# Altium schematic sheet Controlador temp.SchDoc
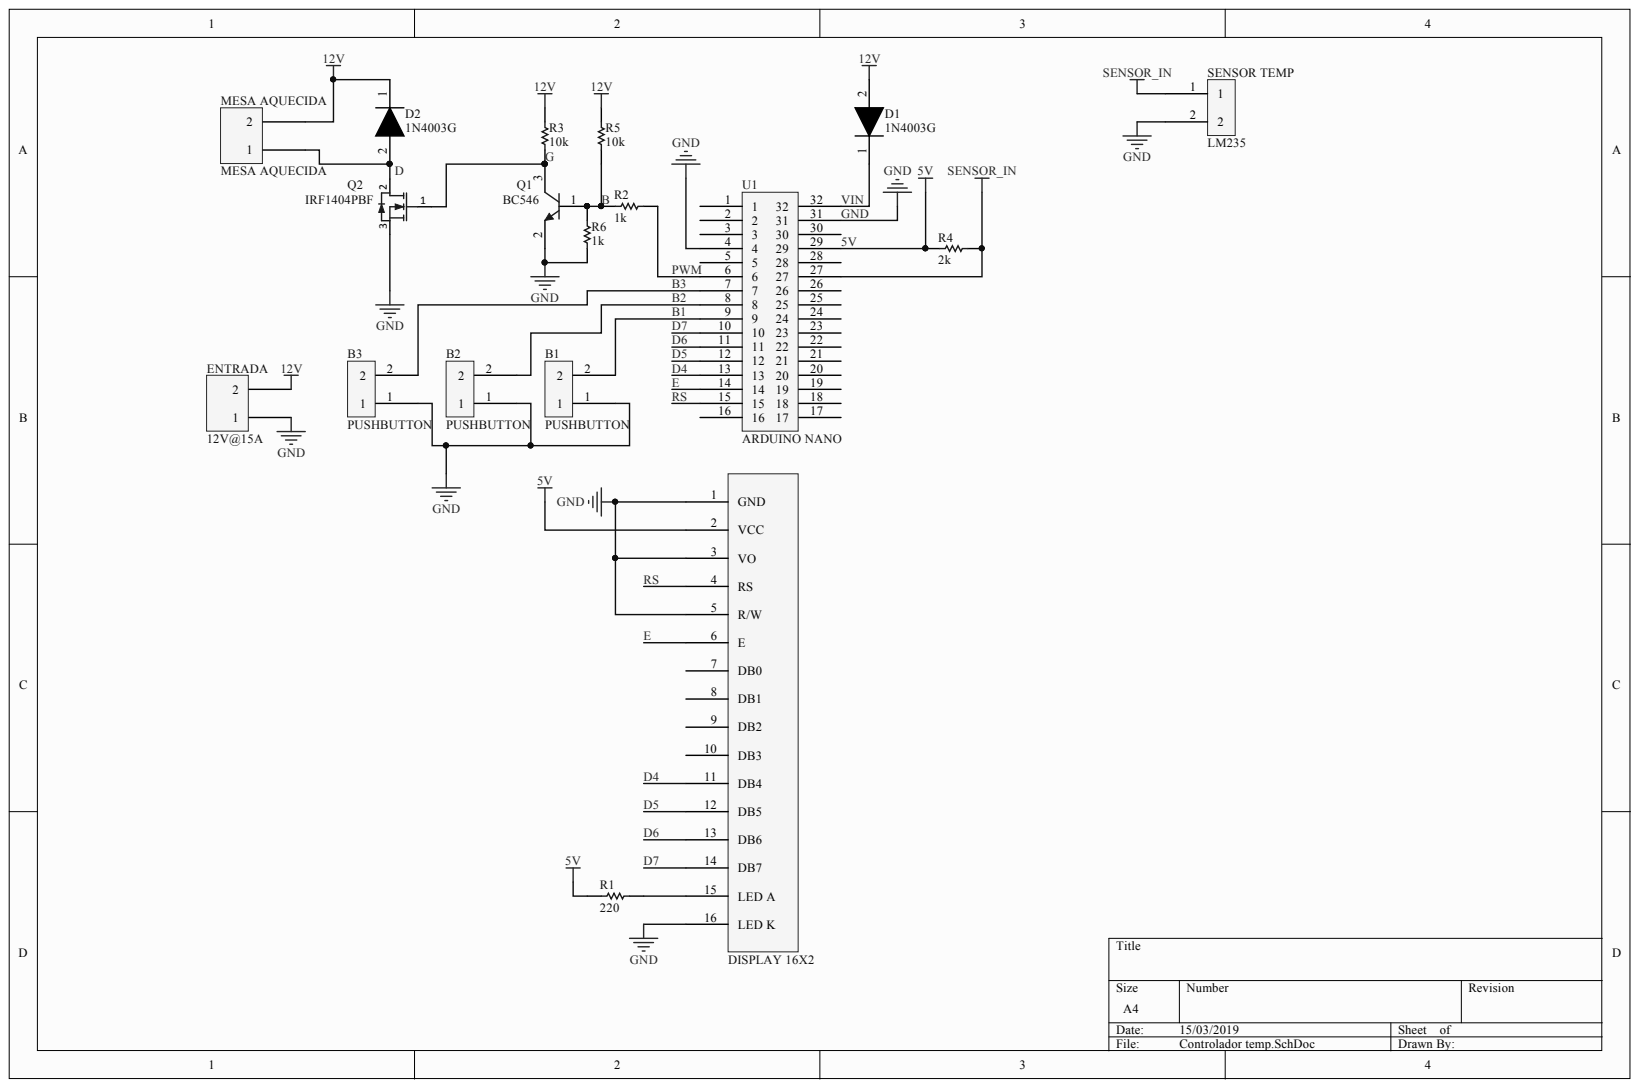
[diagram p0: Controlador temp.SchDoc]

## Parts
B1  PUSHBUTTON  [PCB-55uug6ujkbv21exb3umc-1]
B2  PUSHBUTTON  [PCB-55uug6ujkbv21exb3umc-1]
B3  PUSHBUTTON  [PCB-55uug6ujkbv21exb3umc-1]
D1  1N4003G  [ONSC-AXIAL_LEAD-2-59-10_P]
D2  1N4003G  [ONSC-AXIAL_LEAD-2-59-10_P]
DISPLAY_16X2  umex LCM-S01602DTR/A  [PCB-y1m8ga7es579d0ps2ff7-1]  (value not drawn)
ENTRADA  12V@15A  [PCB-0kdx5sneyudsmu18l6zv-1]
MESA_AQUECIDA  MESA AQUECIDA  [PCB-0kdx5sneyudsmu18l6zv-1]
Q1  BC546  [ONSC-SOT-23-3-318-08_V]
Q2  IRF1404PBF  [PCB-g2h4zyiuoczyb2hbn5hw-1]
R1  220  [PCB-05b3x8t5yofr83vuhvuz-1]
R2  1k  [PCB-05b3x8t5yofr83vuhvuz-1]
R3  10k  [PCB-05b3x8t5yofr83vuhvuz-1]
R4  2k  [PCB-05b3x8t5yofr83vuhvuz-1]
R5  10k  [PCB-05b3x8t5yofr83vuhvuz-1]
R6  1k  [PCB-05b3x8t5yofr83vuhvuz-1]
SENSOR_TEMP  LM235  [PCB-55uug6ujkbv21exb3umc-1]
U1  ARDUINO NANO  [DIP32]

## Nets
12V: D1.2 D2.1 ENTRADA.2 MESA_AQUECIDA.2 R3.2 R5.2
SENSOR_IN: R4.1 SENSOR_TEMP.1 U1.27
5V: DISPLAY_16X2.2(VCC) R1.2 R4.2 U1.29
B: Q1.1 R2.1 R5.1 R6.2
B1: B1.2 U1.9
B2: B2.2 U1.8
B3: B3.2 U1.7
D4: DISPLAY_16X2.11(DB4) U1.13
D5: DISPLAY_16X2.12(DB5) U1.12
D6: DISPLAY_16X2.13(DB6) U1.11
D7: DISPLAY_16X2.14(DB7) U1.10
E: DISPLAY_16X2.6(E) U1.14
G: Q1.3 Q2.1 R3.1
GND: B1.1 B2.1 B3.1 DISPLAY_16X2.1(GND) DISPLAY_16X2.3(VO) DISPLAY_16X2.5(R/W) DISPLAY_16X2.16(LED_K) ENTRADA.1 Q1.2 Q2.3 R6.1 SENSOR_TEMP.2 U1.4 U1.31
PWM: R2.2 U1.6
RS: DISPLAY_16X2.4(RS) U1.15
VIN: D1.1 U1.32
NetD2_2: D2.2 MESA_AQUECIDA.1 Q2.2
NetDISPLAY 16X2_15: DISPLAY_16X2.15(LED_A) R1.1
D:

Not connected: DISPLAY_16X2 7(DB0) 8(DB1) 9(DB2) 10(DB3); U1 1 2 3 5 16 17 18 19 20 21 22 23 24 25 26 28 30

## Library data of the parts
B1-B3 SENSOR_TEMP = CMP-e5782e1080433abf-3: AMPMODU HE14 Series, 2.54mm Pitch 2 Way 1 Row Straight PCB Header, Solder Term; Manufacturer Part Number: 281695-2; ELV Compliant: Compliant; Gender: Male; Lead-Free Status: Lead Free; Number of Contacts: 2; Color: Blue; Component Kind: Standard; Contact Material: Phosphor Bronze; Contact Plating: Tin, Tin over Nickel; Flammability Rating: UL 94 V-0; Housing Color: Blue; Housing Material: Thermoplastic; Library Name: CommunityVault; Lifecycle Status: Active; Mounting Style: Through Hole; Number of Positions: 2; OctopartID: e5782e1080433abf; Octopart URL: https://octopart.com/281695-2-te+connectivity-39993437; Operating Temperature: -55.0 °C to 105 °C; Orientation: Vertical; Packaging: Bulk; Pin Pitch: 2.54 mm; REACH SVHC Compliance: No SVHC; RoHS: Compliant
D1 D2 = CMP-816876-3: Standard Recovery Rectifier, 2-Pin Axial_Lead, Pb-Free, Bulk Bag; Current Rating: 1.0 A; Lead-Free Status: Lead Free; Voltage Rating (DC): 200.0 V; Case/Package: DO-41; Component Kind: Standard; Halogen Free Status: Halogen Free; Library Name: CommunityVault; Lifecycle Status: Active; Mounting Style: Axial; OctopartID: e7b52ebfc5fc3872; Octopart Url: https://octopart.com/1n4003g-on+semiconductor-1839; Packaging: Bulk; Polarity: Standard; RoHS: Compliant
DISPLAY_16X2 = CMP-3919f0112e87e6de-3: Module, LCD; 16 x 2 mm; 5 x 8; 5 V (Typ.); 2 mA (Typ.); 4.2 V @50 degC (Min.); Character Size-Height: 5.56 mm; Lead-Free Status: Lead Free; Supply Voltage (DC): 5.00 V; Component Kind: Standard; Library Name: CommunityVault; Manufacturer Part Number: LCM-S01602DTR/A; OctopartID: 3919f0112e87e6de; Octopart URL: http://octopart.com/lcm-s01602dtr%2Fa-lumex-144120; Packaging: Bulk; RoHS: Compliant; Size-Height: 8.80 mm; Size-Length: 80.0 mm; Size-Width: 36.0 mm
ENTRADA MESA_AQUECIDA = CMP-369bfdc835bbe95c-8: Wire to Board 2 Position 5 mm Pitch 30-16 AWG Euro Style Terminal Block; Manufacturer Part Number: 282836-2; Current Rating: 13.5 A; ELV Compliant: Compliant; Lead-Free Status: Lead Free; Number of Contacts: 2; Pin Pitch: 0.005 m; Voltage Rating (AC): 250.0 V; Wire Gauge: 15.0 AWG; Color: Green; Component Kind: Standard; Contact Material: Brass; Contact Plating: Tin; Flammability Rating: UL 94 V-0; Housing Color: Green; Housing Material: Nylon 6/6; Insulation Material: Nylon; Library Name: CommunityVault; Lifecycle Status: Active; Mounting Style: PCB; Number of Positions: 2; OctopartID: 369bfdc835bbe95c; Octopart URL: http://octopart.com/282836-2-te+connectivity-39396934; Orientation: Right Angle; REACH SVHC Compliance: No SVHC; RoHS: Compliant
Q1 = CMP-857852-2: High Voltage Transistor, NPN Silicon, 3-Pin SOT-23, Pb-Free, Tape and Reel; Current Rating: 0.6 A; Lead-Free Status: Lead Free; Power Dissipation: 0.225 W; Voltage Rating (DC): 160.0 V; Case/Package: SOT-23; Component Kind: Standard; Library Name: CommunityVault; Lifecycle Status: Active; Mounting Style: Surface Mount; Number of Pins: 3; OctopartID: f44d3d479dab2b8a; Octopart Url: https://octopart.com/mmbt5551lt1g-on+semiconductor-19584; REACH SVHC Compliance: No SVHC; RoHS: Compliant
Q2 = CMP-831567-45: MOSFET, Power; N-Ch; VDSS 40V; RDS(ON) 0.0035Ohm; ID 202A; TO-220AB; PD 333W; VGS +/-20; Breakdown Voltage (Drain to Source): 40.0 V; Breakdown Voltage [Gate to Source]: -20.0 V to 20.0 V; Current Rating: 162 A; Drain to Source Resistance (on) (Rds): 3.50 m?; Lead-Free Status: Lead Free; Polarity: N-Channel; Power Dissipation: 200 W; Rise Time: 140 ns; Component Kind: Standard; Continuous Drain Current (Ids): 202 A; Drain to Source Voltage (Vds): 40.0 V; Library Name: CommunityVault; Lifecycle Status: Active; Manufacturer Part Number: IRF1404PBF; Mounting Style: Through Hole; Number of Pins: 3; OctopartID: 89094073d46d56ce; Octopart URL: http://octopart.com/irf1404pbf-international+rectifier-7370754; Packaging: Bulk; Part Family: IRF1404; REACH SVHC Compliance: No SVHC; RoHS: Compliant; Voltage Rating (DC): 40.0 V
R1-R6 = CMP-0f53c4ecec38d094-1: Res, Thin Film, 86k6, 0.1%, 0.25w, 0805; Manufacturer Part Number: RP73PF2A86K6BTDF; Power Rating: 250 mW; Resistance: 86.6 k?; Voltage Rating (DC): 150 V; Case/Package: 0805, 2012; Component Kind: Standard; Library Name: CommunityVault; Lifecycle Status: Not Listed by Manufacturer; Mounting Style: Surface Mount; OctopartID: 0f53c4ecec38d094; Octopart URL: http://octopart.com/rp73pf2a86k6btdf-te+connectivity-45467149; Packaging: Cut Tape (CT); REACH SVHC Compliance: No SVHC; Resistance Tolerance: ±0.1%; RoHS: Compliant
U1 = CMP-6c34a535fc45b3f3-1: Conn DIP Socket SKT 32 POS 2.54mm Solder ST Thru-Hole; Manufacturer Part Number: 4832-6004-CP; Gender: Female; Lead-Free Status: Lead Free; Mounting Angle: 180 °; Number of Contacts: 32; Component Kind: Standard; Contact Material: Phosphor Bronze; Contact Plating: Tin; Library Name: FavoritesLibrary; Mounting Style: Solder, Through Hole; Number of Pins: 32; OctopartID: 6c34a535fc45b3f3; Octopart URL: http://octopart.com/4832-6004-cp-3m-767255; Packaging: Tube; Pin Pitch: 2.54 mm; RoHS: Compliant; Size-Height: 5.08 mm; Size-Length: 40.5 mm; Size-Width: 17.8 mm
